# Altium schematic sheet WiFi-LED-rec-SCH.SchDoc(WiFi-LED-rec-SCH)
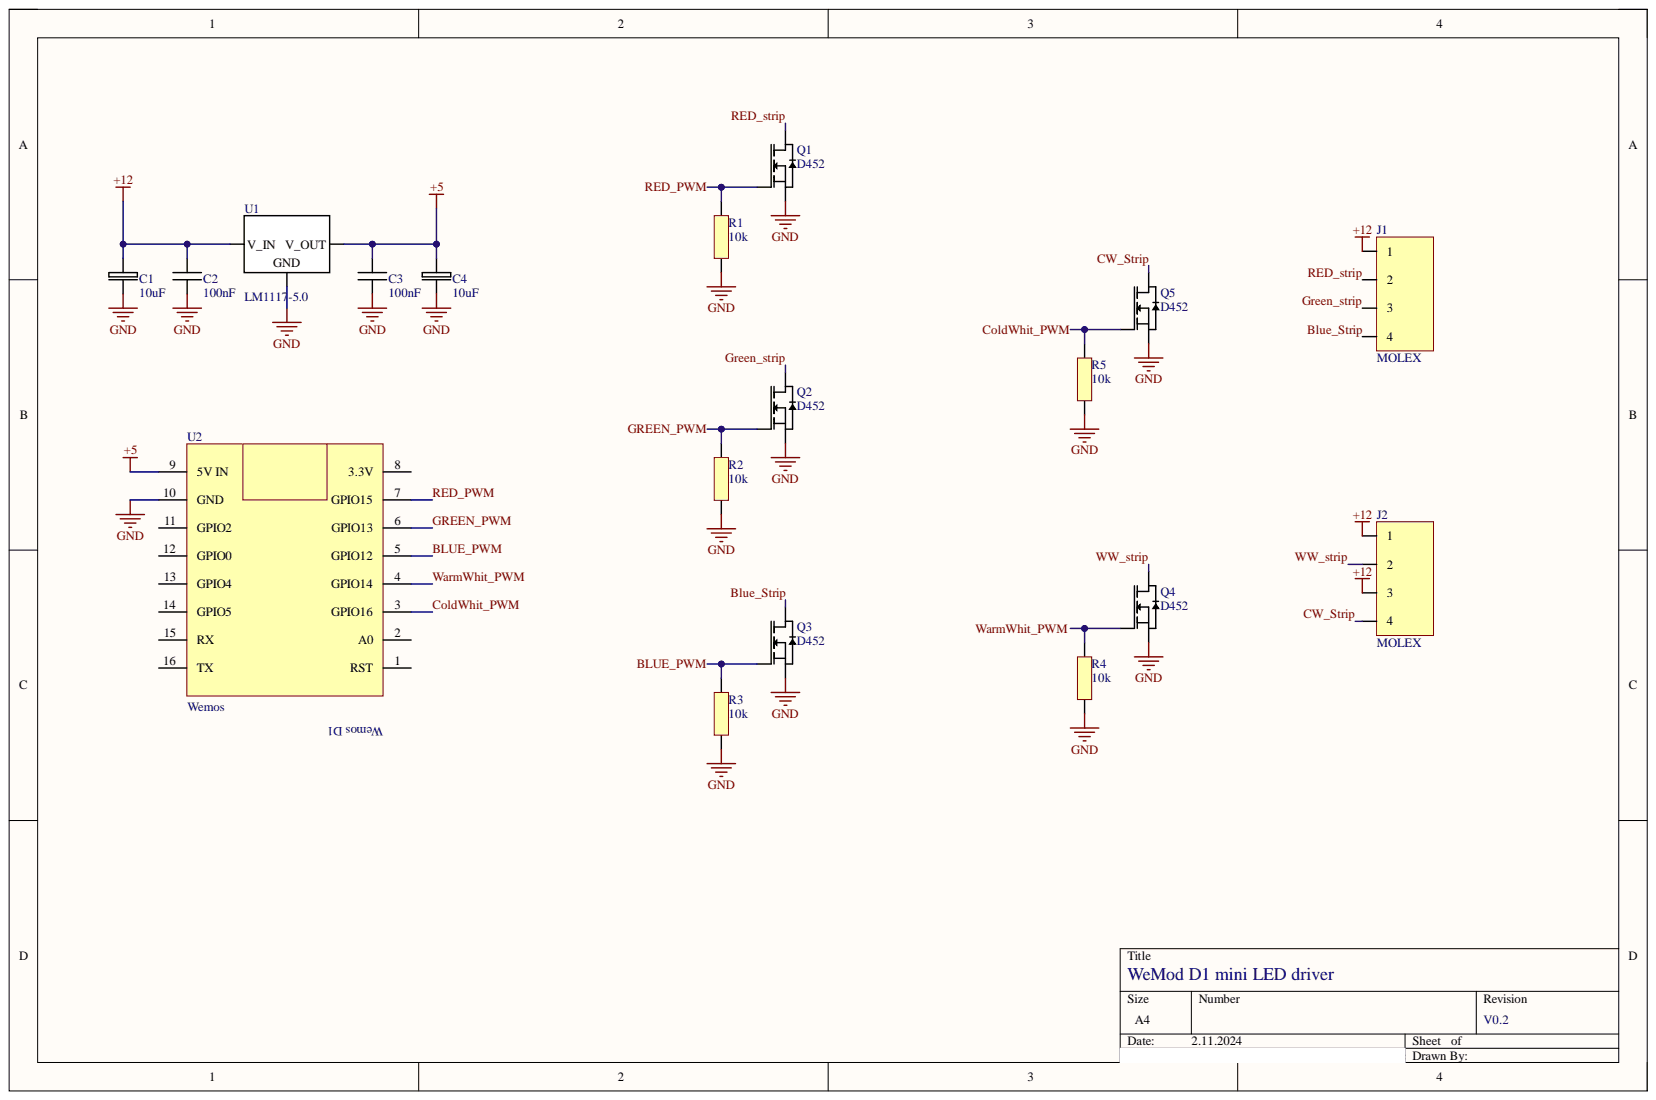
[diagram p0: WiFi-LED-rec-SCH.SchDoc]

## Parts
C1  Capacitance: 10uF  [CAPACITOR TYPE A]  (hidden comment: 100uF)
C2  Capacitance: 100nF  [1206 SMD]  (hidden comment: Ceramic Capacitor)
C3  Capacitance: 100nF  [1206 SMD]  (hidden comment: Ceramic Capacitor)
C4  Capacitance: 10uF  [CAPACITOR TYPE A]  (hidden comment: Electrolitic Capacitor)
J1  MOLEX  [4PIN MOLEX]
J2  MOLEX  [4PIN MOLEX]
Q1  D452  [DPAK252]
Q2  D452  [DPAK252]
Q3  D452  [DPAK252]
Q4  D452  [DPAK252]
Q5  D452  [DPAK252]
R1  10k  [1206 SMD]
R2  10k  [1206 SMD]
R3  10k  [1206 SMD]
R4  10k  [1206 SMD]
R5  10k  [1206 SMD]
U1  LM1117-5.0  [SOT223]
U2  Wemos  [WemosD1]

## Nets
+5: C3.2 C4.2 U1.2 U2.9(5V_IN)
+12: C1.2 C2.2 J1.1 J2.1 J2.3 U1.3
GND: C1.1 C2.1 C3.1 C4.1 Q1.3 Q2.3 Q3.3 Q4.3 Q5.3 R1.1 R2.1 R3.1 R4.1 R5.1 U1.1 U2.10(GND)
BLUE_PWM: Q3.1 R3.2 U2.5(GPIO12)
Blue_Strip: J1.4 Q3.2
ColdWhit_PWM: Q5.1 R5.2 U2.3(GPIO16)
CW_Strip: J2.4 Q5.2
GREEN_PWM: Q2.1 R2.2 U2.6(GPIO13)
Green_strip: J1.3 Q2.2
RED_PWM: Q1.1 R1.2 U2.7(GPIO15)
RED_strip: J1.2 Q1.2
WarmWhit_PWM: Q4.1 R4.2 U2.4(GPIO14)
WW_strip: J2.2 Q4.2

Not connected: U2 1(RST) 2(A0) 8(3.3V) 11(GPIO2) 12(GPIO0) 13(GPIO4) 14(GPIO5) 15(RX) 16(TX)

## Library data of the parts
C1 C4 = Electrolitic Capacitor: SMD Capacitor; Component Kind: Standard; Library Name: LOAD_SCH_LIB.SchLib
J1 J2 = 4-PIN MOLEX: Component Kind: Standard; Library Name: WiFi-LED-LIB.SchLib
Q1-Q5 = AOD452: NMOS   Vgs =25V  ID = 55A   RDS < 14mOhm*; Component Kind: Standard; Library Name: WiFi-LED-LIB.SchLib
R1-R5 = Resistor: Component Kind: Standard; Library Name: WiFi-LED-LIB.SchLib
U1 = LM1117-5.0: 5V DC volatage stabilizer; Component Kind: Standard; Library Name: WiFi-LED-LIB.SchLib
C2 C3 = Ceramic Capacitor: Component Kind: Standard; Library Name: LOAD_SCH_LIB.SchLib
U2 = Wemos: Component Kind: Standard; Library Name: Schlib1.SchLib
